# Altium schematic sheet POWERREG.SchDoc
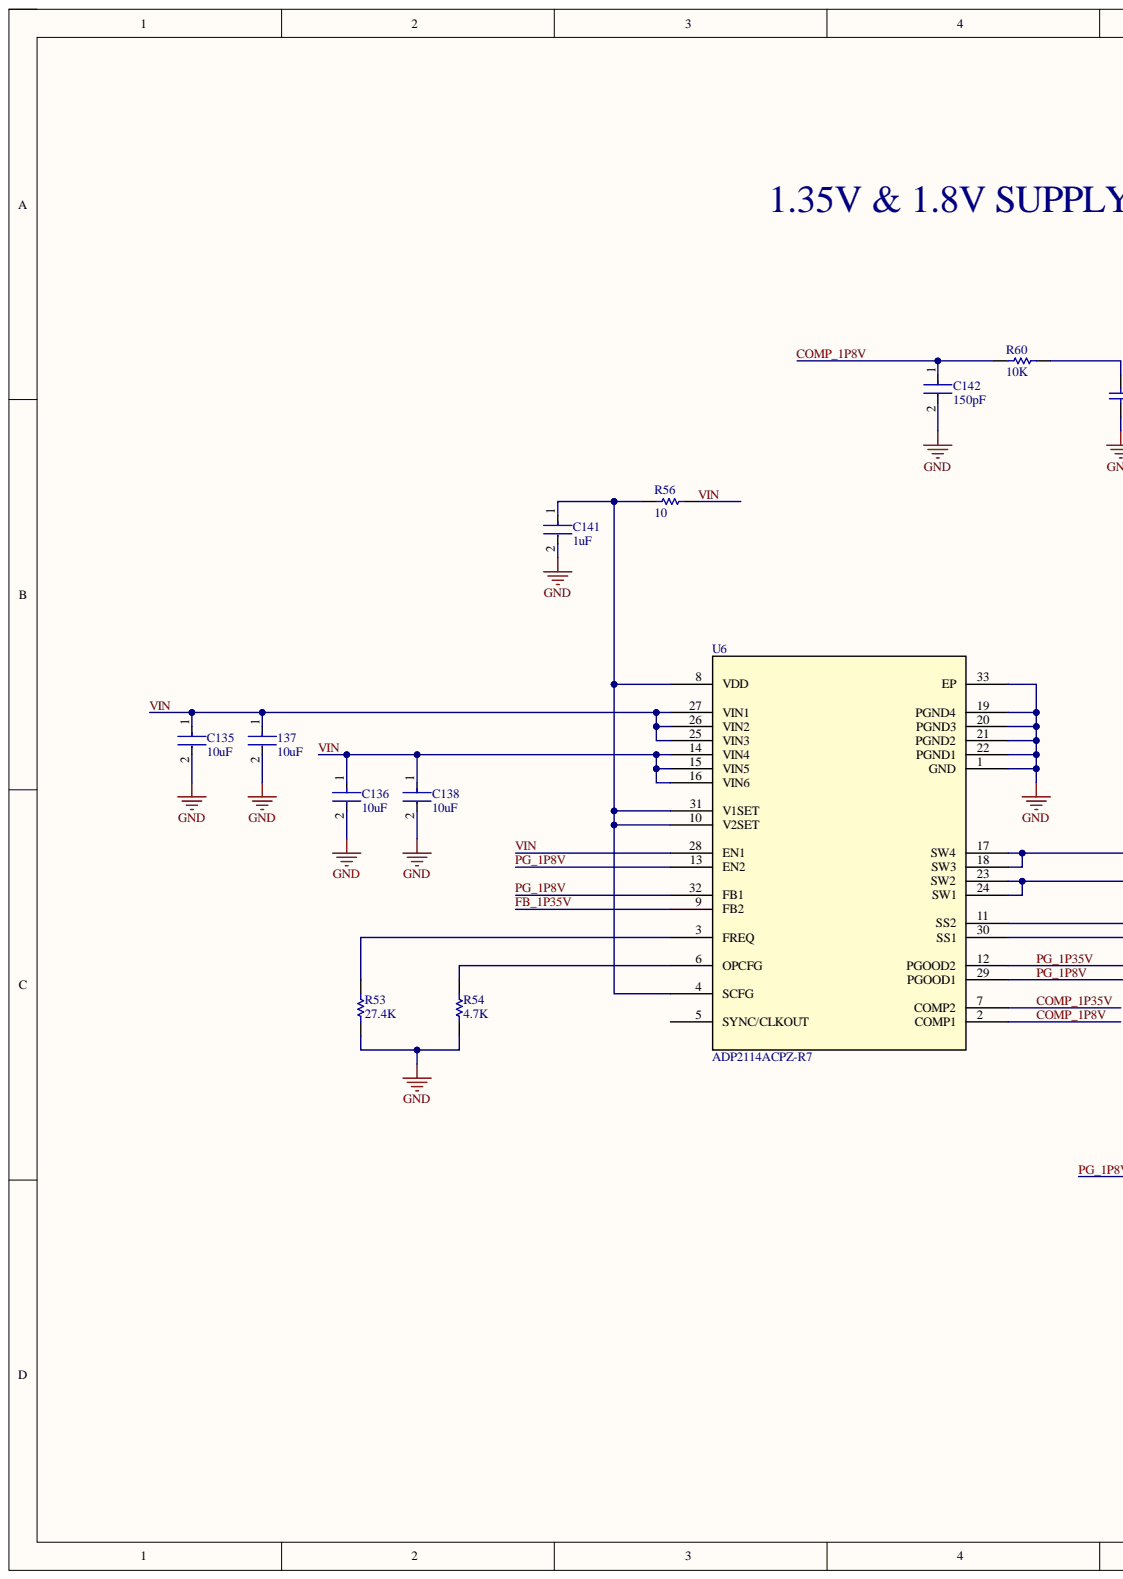
[diagram p0: POWERREG.SchDoc, left]
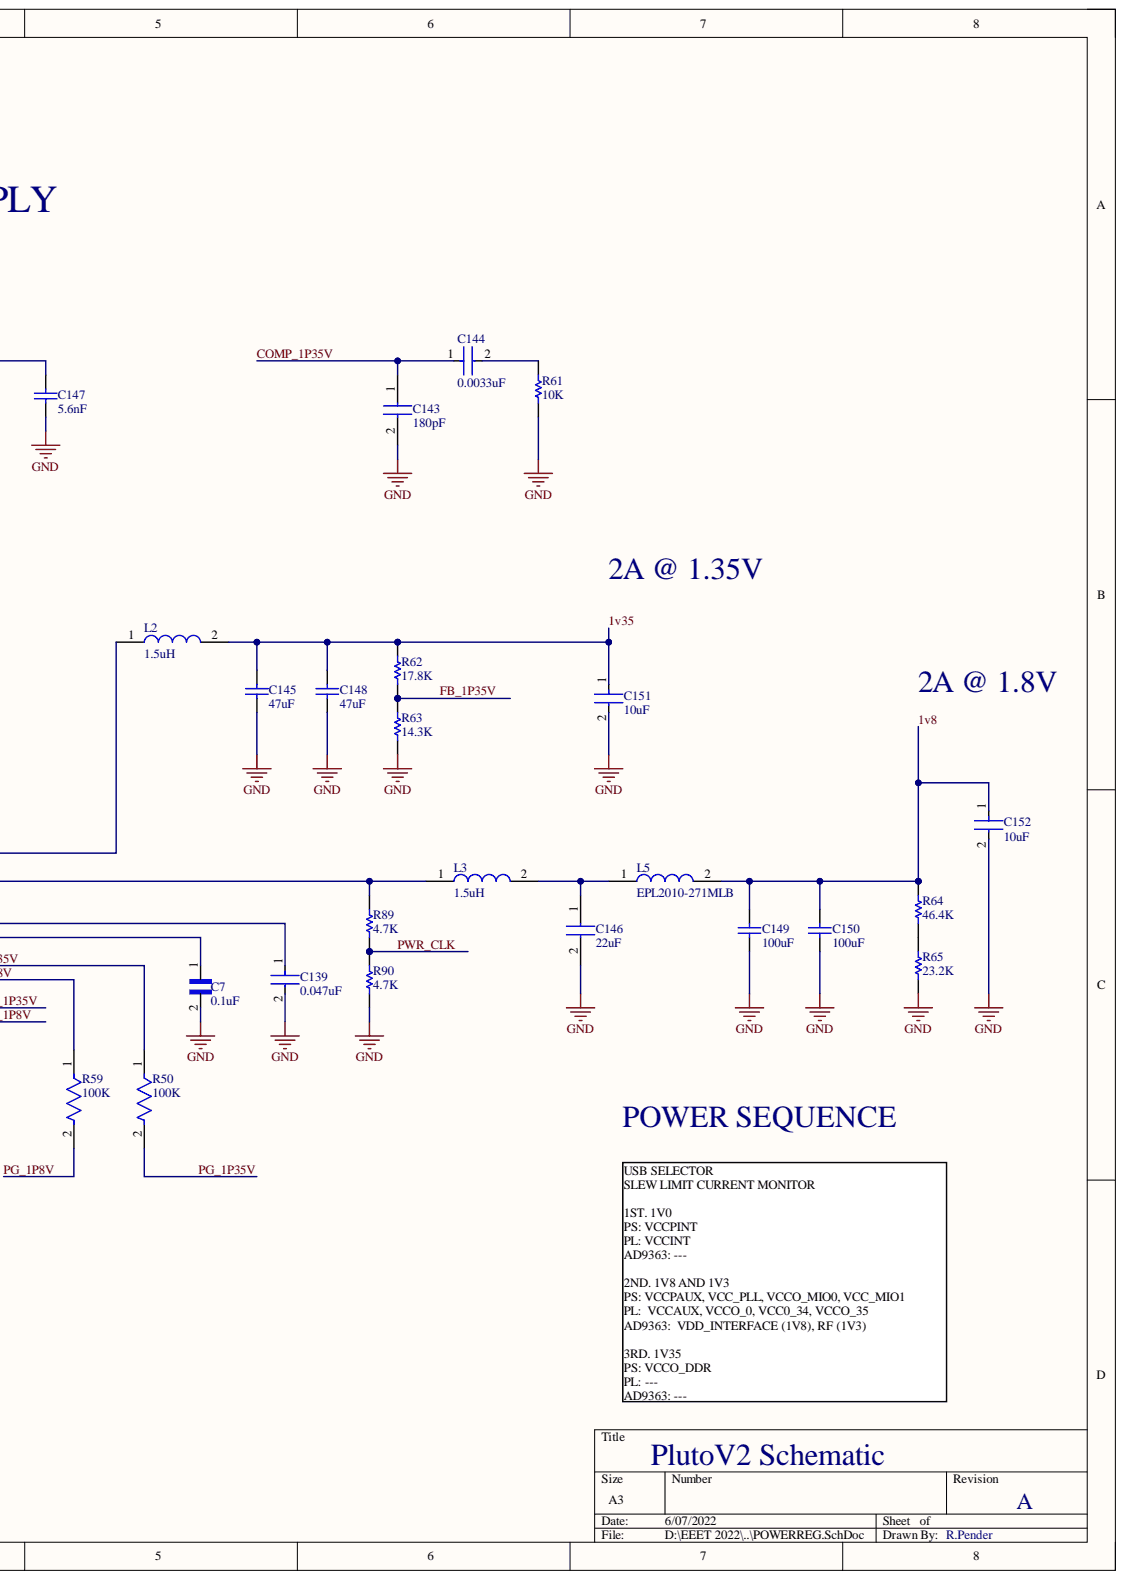
[diagram p1: POWERREG.SchDoc, right]

## Parts
137  10uF  [FP-GRM188-0_15-MFG]
C7  0.1uF  [CAPC0603X33N]
C135  10uF  [FP-GRM188-0_15-MFG]
C136  10uF  [FP-GRM188-0_15-MFG]
C138  10uF  [FP-GRM188-0_15-MFG]
C139  0.047uF  [FP-GCM155-0_05-IPC_C]
C141  1uF  [FP-0402-L_1_0_0_05-W_0_5-IPC_A]
C142  150pF  [FP-GCM155-0_05-IPC_C]
C143  180pF  [CAPC1005X55N]
C144  0.0033uF  [FP-CC0402-MFG]
C145  47uF  [TAYO-JMK212BBJ476MG-T_L]
C146  22uF  [CAPC2012X95N]
C147  5.6nF  [CAPC1005X56X25NL10T15]
C148  47uF  [TAYO-JMK212BBJ476MG-T_L]
C149  100uF  [CAPC3216X190X55ML30T25]
C150  100uF  [CAPC3216X190X55ML30T25]
C151  10uF  [FP-GRM188-0_1-e0_2_0_55-IPC_A]
C152  10uF  [FP-GRM188-0_1-e0_2_0_55-IPC_A]
L2  1.5uH  [XFL4020152MEB]
L3  1.5uH  [XFL4020152MEB]
L5  EPL2010-271MLB  [EPL2010271MLB]
R50  100K  [FP-ERJ2RK-IPC_C]
R53  27.4K  [2-0402]
R54  4.7K  [2-0402]
R56  10  [RESC0603X26X15LL03T05]
R59  100K  [FP-ERJ2RK-IPC_C]
R60  10K  [2-0402]
R61  10K  [2-0402]
R62  17.8K  [3-0603]
R63  14.3K  [3-0603]
R64  46.4K  [2-0402]
R65  23.2K  [2-0402]
R89  4.7K  [2-0402]
R90  4.7K  [2-0402]
U6  ADP2114ACPZ-R7  [CP_32_2_ADI]

## Nets
GND: 137.2 C7.2 C135.2 C136.2 C138.2 C139.2 C141.2 C142.2 C143.2 C145.2 C146.2 C147.2 C148.2 C149.2 C150.2 C151.2 C152.2 R53.2 R54.2 R61.2 R63.2 R65.2 R90.2 U6.1(GND) U6.19(PGND4) U6.20(PGND3) U6.21(PGND2) U6.22(PGND1) U6.33(EP)
1v8: C149.1 C150.1 C152.1 L5.2 R64.1
1v35: C145.1 C148.1 C151.1 L2.2 R62.1
COMP_1P8V: C142.1 R60.1 U6.2(COMP1)
COMP_1P35V: C143.1 C144.1 U6.7(COMP2)
FB_1P35V: R62.2 R63.1 U6.9(FB2)
PG_1P8V: R59.1 R59.2 U6.13(EN2) U6.29(PGOOD1) U6.32(FB1)
PG_1P35V: R50.1 R50.2 U6.12(PGOOD2)
PWR_CLK: R89.2 R90.1
VIN: 137.1 C135.1 C136.1 C138.1 R56.2 U6.14(VIN4) U6.15(VIN5) U6.16(VIN6) U6.25(VIN3) U6.26(VIN2) U6.27(VIN1) U6.28(EN1)
NetC7_1: C7.1 U6.30(SS1)
NetC139_1: C139.1 U6.11(SS2)
NetC141_1: C141.1 R56.1 U6.4(SCFG) U6.8(VDD) U6.10(V2SET) U6.31(V1SET)
NetC144_2: C144.2 R61.1
NetC146_1: C146.1 L3.2 L5.1
NetC147_1: C147.1 R60.2
NetL2_1: L2.1 U6.17(SW4) U6.18(SW3)
NetL3_1: L3.1 R89.1 U6.23(SW2) U6.24(SW1)
NetR53_1: R53.1 U6.3(FREQ)
NetR54_1: R54.1 U6.6(OPCFG)
NetR64_2: R64.2 R65.1

Not connected: U6 5(SYNC/CLKOUT)

## Library data of the parts
137 C135 C136 C138 = CMP-06035-003612-1: Chip Multilayer Ceramic Capacitors for General Purpose, 0603, 10uF, X5R, 15%, 20%, 10V; Capacitance: 10uF; Case Code (Imperial): 0603; Case Code (Metric): 1608; Case/Package: 0603; Component Kind: Standard; Depth: 0.8mm; Dielectric: X5R; Height: 0.8mm; Lead Free: Lead Free; Length: 1.6mm; Library Name: Altium Content Vault; Material: Ceramic; Max Operating Temperature: 85°C; Min Operating Temperature: -55°C; Mount: Surface Mount; Package Quantity: 4000; Packaging: Tape and Reel; Termination: SMD/SMT; Thickness: 0.035inch; Tolerance: 20%; Voltage Rating: 10V; Voltage Rating (DC): 10V; Weight: 0.000222oz; Width: 0.8mm
C7 = GRM033R61C104ME84D: CAP 0.1UF 16VDC X5R 20% SMD 0201 PAPER TAPE; Availability: Unavailable; Component Kind: Standard; Library Name: GRM033R61C104ME84D.IntLib; MP: GRM033R61C104ME84D; Package: None; Price: None
C139 = CMP-2008-03720-2: Ceramic Capacitor for Automotive 47nF ±10% 25VDC X7R 0402 Paper T/R; Voltage: 25V; Capacitance: 47nF; Case Code (Imperial): 0402; Case Code (Metric): 1005; Case/Package: 0402; Component Kind: Standard; Depth: 0.5mm; Dielectric: X7R; Height: 0.5mm; Length: 1mm; Library Name: Altium Content Vault; Max Operating Temperature: 125°C; Min Operating Temperature: -55°C; Mount: Surface Mount; Package Quantity: 1; Packaging: Tape and Reel; Radiation Hardening: No; Ratings: AEC-Q200; REACH SVHC: No SVHC; Termination: SMD/SMT; Thickness: 0.022inch; Tolerance: 10%; Voltage Rating: 25V; Voltage Rating (DC): 25V; Width: 0.02inch
C141 = CMP-14477-000104-2: None; Dielectric: X5R; Tolerance: 10%; Voltage Rating: 16V; Capacitance: 1uF; Case Code (Imperial): 0402; Case Code (Metric): 1005; Case/Package: 0402; Component Kind: Standard; Construction: Flat; Depth: 0.5mm; Halogen Free: Halogen Free; Height: 0.5mm; Length: 1mm; Library Name: Altium Content Vault; Max Operating Temperature: 85°C; Min Operating Temperature: -55°C; Mount: Surface Mount; Number of Pins: 2; Package Quantity: 1; Packaging: Tape and Reel; Radiation Hardening: No; RoHS Compliant: Yes; Series: M; Simulation: EMK105BJ105_V-F; Termination: SMD/SMT; Thickness: 0.022inch; Voltage Rating (DC): 16V; Width: 0.02inch
C142 = CMP-06035-010111-1: Ceramic Capacitor for Automotive 150pF ±1% 50VDC C0G 0402 Paper T/R; Component Kind: Standard; Library Name: Altium Content Vault
C143 = CGJ2B2C0G1H181J050BA: Capacitor; Manufacturer_Name: TDK; Component Kind: Standard; Datasheet Link: https://product.tdk.com/system/files/dam/doc/product/capacitor/ceramic/mlcc/catalog/mlcc_highreliability_general_en.pdf; Height: 0.55mm; Manufacturer_Part_Number: CGJ2B2C0G1H181J050BA
C144 = CMP-1034-01779-2: CAP CER 3300PF 50V X7R 0402; Manufacturer: YAGEO; Manufacturer Part Number: CC0402KRX7R9BB332; Automotive Grade: NA; Capacitance: 3.3 nF; Material: Ceramic; Temperature Coefficient: 30ppm/°C; Tolerance: 0.1; Value: 3.3 nF; Automotive: No; Category: Cap; Component Kind: Standard; ComponentLink2Description: Datasheet; ComponentLink2URL: http://www.yageo.com/documents/recent/UPY-GP_NP0_16V-to-50V_16.pdf; Device Class L1: Passive Components; Device Class L2: Capacitors; Device Class L3: Ceramic Capacitors; Digikey Description: CAP CER 3300PF 50V X7R 0402; Footprint Url: http://www.yageo.com/exep/pages/download/literatures/UPY-C_GEN_24.pdf; Height: 0.55mm; Ipc Land Pattern Name: CAPC10050X50; Lead Free: Yes; Library Name: Altium Content Vault; Mouser Description: Multilayer Ceramic Capacitors MLCC - SMD/SMT 3.3nF 50V X7R 10%; Octopart: https://octopart.com/cc0402krx7r9bb332-yageo-192887; Package: CC0402; RoHS: TRUE; Temperature: -55°C to +125°C; Temperature Characteristic: X7R; Temperature Range High: +125°C; Temperature Range Low: -55°C; Voltage Rating: 50 V
C145 C148 = CMP-2000-06498-1: High Value Multilayer Ceramic Capacitor, 47 uF, +/-20%, 6.3 V, -55 to 85 degC, 2-Pin SMD (0805), RoHS, Tape and Reel; Manufacturer: Taiyo Yuden; PCN Part Number: X5R,X7RDielectrics23/Jan/2008; Capacitance: 47µF; Catalog Drawings: xMKSeries_0805_1.25; Kits: 587-1797-KIT-ND-KITCAPCERHICAP0603&0805S; Online Catalog: MSeries; Other Names: 587-1779-1; Temperature Coefficient: X5R; Tolerance: ±20%; Voltage - Rated: 6.3V; Applications: GeneralPurpose; Category: Capacitors; Component Kind: Standard; Datasheet URL: http://datasheet.octopart.com/JMK212BJ476MG-T-Taiyo-Yuden-datasheet-62109412.pdf; Datasheet Version: 10/2015; Family: CeramicCapacitors; Features: -; Height - Seated (Max): -; Lead Spacing: -; Lead Style: -; Library Name: Altium Content Vault; Manufacturer URL: http://www.t-yuden.com/; Mounting Technology: SurfaceMount; Mounting Type: SurfaceMount,MLCC; Operating Temperature: -55°C~85°C; Package / Case: 0805(2012Metric); Package Description: 2-Pin SMD, Body 2.2 x 1.45 mm; Package Reference: 0805; Packaging: CutTape(CT); Ratings: -; Series: M; Size / Dimension: 0.079"Lx0.049"W(2.00mmx1.25mm); Standard Package: 1; Thickness (Max): 0.053"(1.35mm)
C146 = CL21A226KPCLRNC: Capacitor; Manufacturer_Name: Samsung Electro-Mechanics; Component Kind: Standard; Datasheet Link: https://datasheet.datasheetarchive.com/originals/distributors/DKDS-19/374283.pdf; Height: 0.95mm; Manufacturer_Part_Number: CL21A226KPCLRNC
C147 = CMP-2008-02976-1: Manufacturer: AVX Interconnect / Elco; Part Number: 04025C562JAT2A; Component Kind: Standard; Library Name: Altium Content Vault
C149 C150 = CMP-1662-00011-2: Chip Capacitor, 100 uF, +/- 20%, 6.3 V, -55 to 85 degC, 1206 (3216 Metric), RoHS, Tape and Reel; PartNumber: GRM31CR60J107ME39L; Case\/Package: 1206; Type (Capacitors): X5R; Voltage Rating: 6.3 V; Capacitance: 100 uF; Case Code (Imperial): 1206; Case Code (Metric): 3216; Component Kind: Standard; ComponentLink1Description: Manufacturer URL; ComponentLink1URL: http://www.murata.com/; ComponentLink2Description: Datasheet; ComponentLink2URL: http://psearch.en.murata.com/capacitor/product/GRM31CR60J107ME39%23.pdf; DatasheetVersion: 09/2016; Height: 1.6 mm; Length: 3.2 mm; Library Name: Altium Content Vault; Max Operating Temperature: 85 degC; Min Operating Temperature: -55 degC; Mount: Surface Mount; Mounting Technology: Surface Mount; Package Quantity: 2000; PackageDescription: 2-Pin Surface Mount Device, Body 3.2 x 1.6 mm; PackageReference: 1206; Packaging: Tape and Reel; Pins: 2; Radiation Hardening: No; REACH SVHC: No SVHC; RoHSCompliant: true; Tolerance: 20%; Voltage Rating (DC): 6.3 V; Width: 1.6 mm
C151 C152 = CMP-2006-02792-2: Chip Multilayer Ceramic Capacitors for General Purpose, 0603, 10uF, X5R, 15%, 20%, 6.3V; Capacitance: 10uF; Case Code (Imperial): 0603; Case Code (Metric): 1608; Case/Package: 0603; Component Kind: Standard; Depth: 0.8mm; Dielectric: X5R; Height: 0.8mm; Lead Free: Lead Free; Length: 1.6mm; Library Name: Altium Content Vault; Material: Ceramic; Max Operating Temperature: 85°C; Min Operating Temperature: -55°C; Mount: Surface Mount; Package Quantity: 4000; Packaging: Tape and Reel; Radiation Hardening: No; REACH SVHC: No SVHC; Termination: SMD/SMT; Thickness: 0.035inch; Tolerance: 20%; Voltage: 6.3V; Voltage Rating: 6.3V; Voltage Rating (DC): 6.3V; Width: 0.031inch
L2 L3 = XFL4020-152MEB: Inductor; Manufacturer_Name: COILCRAFT; Component Kind: Standard; Datasheet Link: https://componentsearchengine.com//XFL4020-152MEB.pdf; Height: 2.1mm; Manufacturer_Part_Number: XFL4020-152MEB; Mouser2 Part Number: 994-XFL4020-152MEB; Mouser2 Price/Stock: https://www.mouser.com/Search/Refine.aspx?Keyword=994-XFL4020-152MEB
L5 = EPL2010-271MLB: Inductor; Manufacturer_Name: COILCRAFT; Component Kind: Standard; Datasheet Link: https://componentsearchengine.com/Datasheets/2/EPL2010-271MLB.pdf; Height: 1.05mm; Manufacturer_Part_Number: EPL2010-271MLB
R50 R59 = CMP-2002-00608-4: RES SMD 100K OHM 1% 1/10W 0402; Max Power Dissipation: 100mW; Resistance: 100kR; Temperature Coefficient: 100ppm/°C; Voltage Rating: 50V; Case Code (Imperial): 0402; Case Code (Metric): 1005; Case/Package: 0402; Component Kind: Standard; Composition: Thick Film; Contact Plating: Tin; Depth: 0.5mm; Features: Automotive AEC-Q200; Height: 0.35mm; Lead Free: Lead Free; Length: 1mm; Library Name: Altium Content Vault; Max Operating Temperature: 155°C; Military Standard: Not; Min Operating Temperature: -55°C; Mount: Surface Mount; Number of Pins: 2; Number of Terminations: 2; Package Quantity: 1; Packaging: Tape and Reel; Power Rating: 100mW; Radiation Hardening: No; REACH SVHC: No SVHC; Termination: SMD/SMT; Tolerance: 1%; Voltage Rating (DC): 50V; Width: 0.5mm
R53 R54 R60 R61 R64 R65 R89 R90 = ERJ2RK: Precision Thick Film Chip Resistor, 10 Ohm to 1M Ohm Range, 1% and 0.5% Tolerance, 0402 Size, 0.063 W; Code_IPC: 1005 [0402]; Component Kind: Standard; ComponentLink1Description: Manufacturer Link; ComponentLink1URL: http://www.panasonic.com/industrial/components/resistive_products.htm; ComponentLink2Description: Datasheet; ComponentLink2URL: http://www.panasonic.com/industrial/components/pdf/AOA0000CE2.pdf; LatestRevisionDate: 19-Mar-2004; LatestRevisionNote: Silkscreen size reduced.; Library Name: Panasonic Resistor.IntLib; PackageDocument: Mar-2003; PackageReference: 2-0402; Published: 17-Dec-2003; Signal Integrity: Res; Simulation: RESISTOR; Publisher: Altium Limited
R56 = CMP-2004-03448-1: Manufacturer: Panasonic; Part Number: ERJ1GNF10R0C; Component Kind: Standard; Library Name: Altium Content Vault
R62 R63 = ERJ3EK: Precision Thick Film Chip Resistor, 10 Ohm to 1M Ohm Range, 1% and 0.5% Tolerance, 0603 Size, 0.063 W; Code_IPC: 1608 [0603]; Component Kind: Standard; ComponentLink1Description: Manufacturer Link; ComponentLink1URL: http://www.panasonic.com/industrial/components/resistive_products.htm; ComponentLink2Description: Datasheet; ComponentLink2URL: http://www.panasonic.com/industrial/components/pdf/AOA0000CE2.pdf; LatestRevisionDate: 19-Mar-2004; LatestRevisionNote: Silkscreen size reduced.; Library Name: Panasonic Resistor.IntLib; PackageDocument: Mar-2003; PackageReference: 3-0603; Published: 17-Dec-2003; Signal Integrity: Res; Simulation: RESISTOR; Publisher: Altium Limited
U6 = ADP2114ACPZ-R7: No Description Available; Mfr_Name: Analog Devices Inc; Component Kind: Standard; Digi-Key_Part_Number_1: ADP2114ACPZ-R7CT-ND; Digi-Key_Part_Number_2: ADP2114ACPZ-R7DKR-ND; Digi-Key_Part_Number_3: ADP2114ACPZ-R7TR-ND; Digi-Key_Part_Number_4: 2156-ADP2114ACPZ-R7-ND; Library Name: ADP2114ACPZ-R7.SchLib; Manufacturer_Part_Number: ADP2114ACPZ-R7; RefDes: RefDes; Type: DEV; Copyright: Copyright (C) 2022 Ultra Librarian. All rights reserved.
